# Altium schematic sheet 04_HDMI_Display.SchDoc
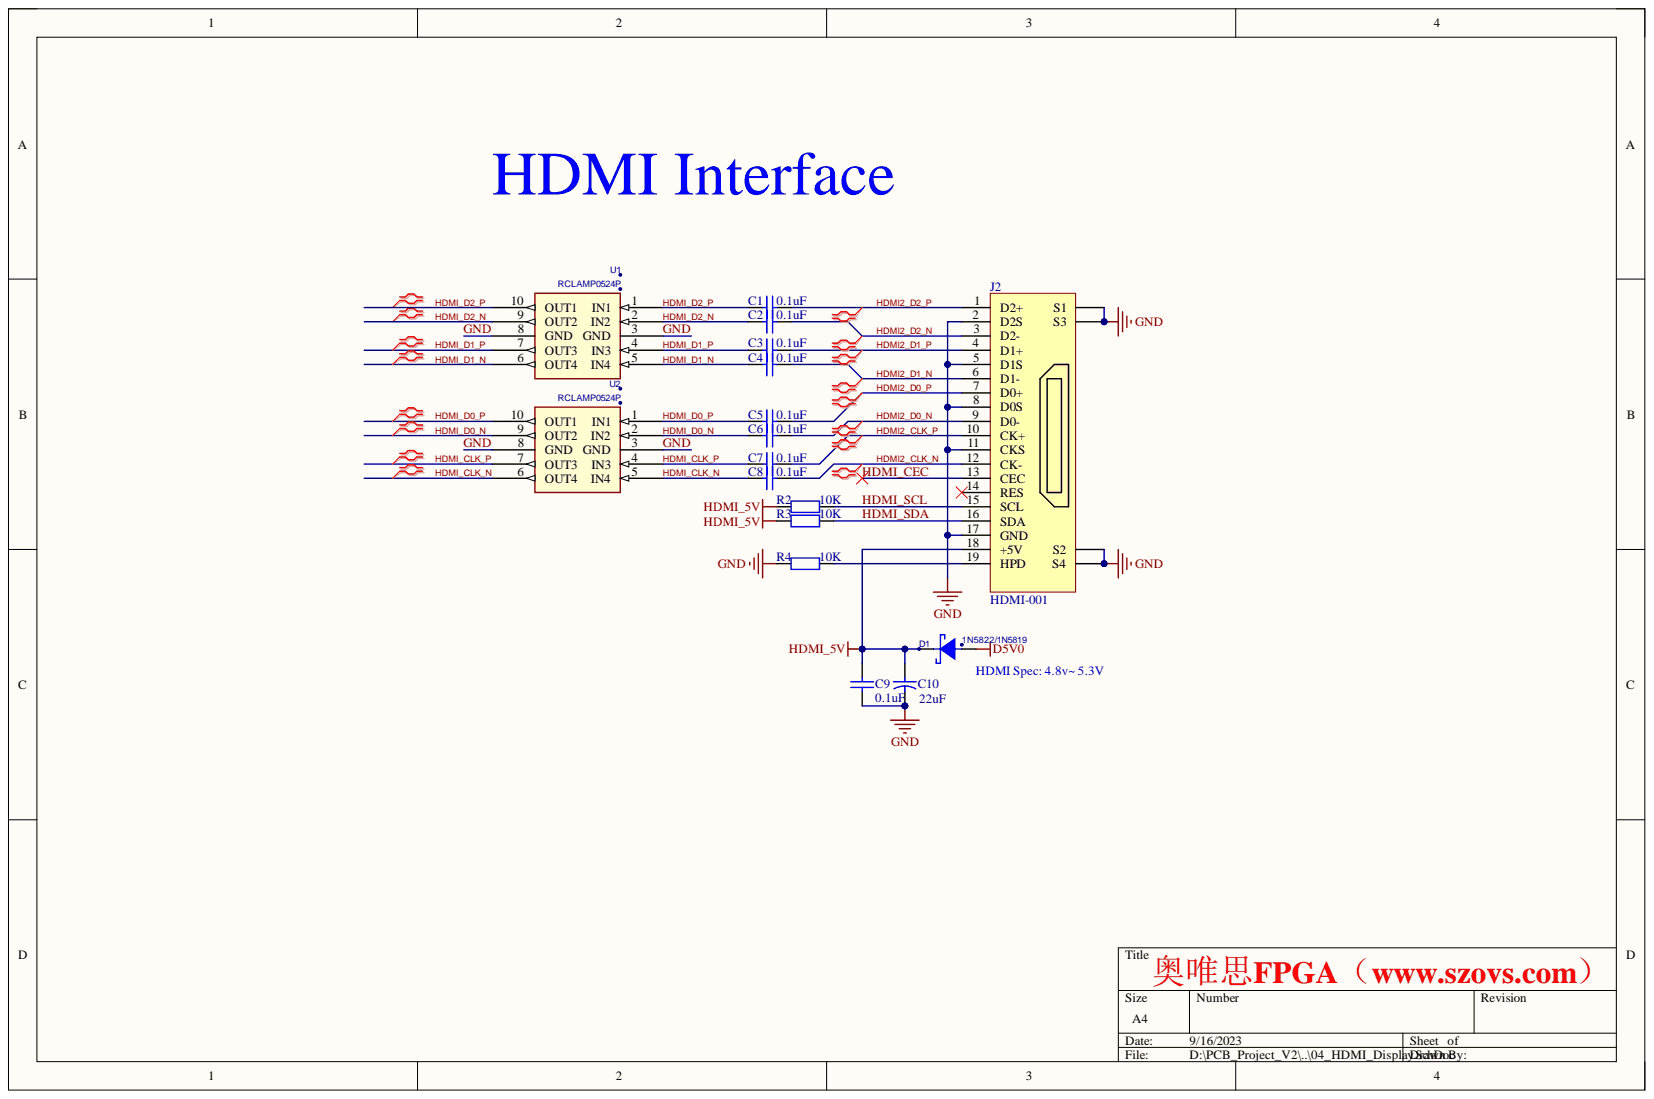
[diagram p0: 04_HDMI_Display.SchDoc]

## Parts
C1  0.1uF  [R0402]
C2  0.1uF  [R0402]
C3  0.1uF  [R0402]
C4  0.1uF  [R0402]
C5  0.1uF  [R0402]
C6  0.1uF  [R0402]
C7  0.1uF  [R0402]
C8  0.1uF  [R0402]
C9  0.1uF  [R0402]
C10  22uF  [R0805]
D1  1N5822/1N5819  [SOD323]
J2  HDMI-001  [HDMI_A]
R2  10K  [R0402]
R3  10K  [R0402]
R4  10K  [R0402]
U1  RCLAMP0524P  [SLP2510P8_10]
U2  RCLAMP0524P  [SLP2510P8_10]

## Nets
D5V0: D1.1
HDMI_5V: C9.2 C10.1 D1.2 J2.18(+5V) R2.2 R3.2
GND: C9.1 C10.2 J2.0(S4) J2.2(D2S) J2.5(D1S) J2.8(D0S) J2.11(CKS) J2.17(GND) R4.2 U1.3(GND) U1.8(GND) U2.3(GND) U2.8(GND)
HDMI2_CLK_N: C8.1 J2.12(CK-)
HDMI2_CLK_P: C7.1 J2.10(CK+)
HDMI2_D0_N: C6.1 J2.9(D0-)
HDMI2_D0_P: C5.1 J2.7(D0+)
HDMI2_D1_N: C4.1 J2.6(D1-)
HDMI2_D1_P: C3.1 J2.4(D1+)
HDMI2_D2_N: C2.1 J2.3(D2-)
HDMI2_D2_P: C1.1 J2.1(D2+)
HDMI_CEC: J2.13(CEC)
HDMI_CLK_N: C8.2 U2.5(IN4) U2.6(OUT4)
HDMI_CLK_P: C7.2 U2.4(IN3) U2.7(OUT3)
HDMI_D0_N: C6.2 U2.2(IN2) U2.9(OUT2)
HDMI_D0_P: C5.2 U2.1(IN1) U2.10(OUT1)
HDMI_D1_N: C4.2 U1.5(IN4) U1.6(OUT4)
HDMI_D1_P: C3.2 U1.4(IN3) U1.7(OUT3)
HDMI_D2_N: C2.2 U1.2(IN2) U1.9(OUT2)
HDMI_D2_P: C1.2 U1.1(IN1) U1.10(OUT1)
HDMI_SCL: J2.15(SCL) R2.1
HDMI_SDA: J2.16(SDA) R3.1
NetJ2_19: J2.19(HPD) R4.1

Not connected: J2 14(RES)

## Library data of the parts
C1-C9 = CAP: Component Kind: Standard; Library Name: Crazy_PCB.SchLib
C10 = CAP2: vaule: 10uF; Component Kind: Standard; Library Name: Crazy_PCB.SchLib
D1 = 1N5817: Component Kind: Standard
J2 = HDMI: Component Kind: Standard; Library Name: Crazy_PCB.SchLib
R2-R4 = RES: Resistor; Value: 33R; Component Kind: Standard; Library Name: Crazy_PCB.SchLib; Published: 33R; Revision: July-2002: Re-released for DXP Platform.; Publisher: Altium Limited
U1 U2 = RCLAMP0524P: Component Kind: Standard
